# Altium schematic sheet 02 DE1-SoC Interface and All Devices.SchDoc("02 DE1-SoC Interface and All Devices")
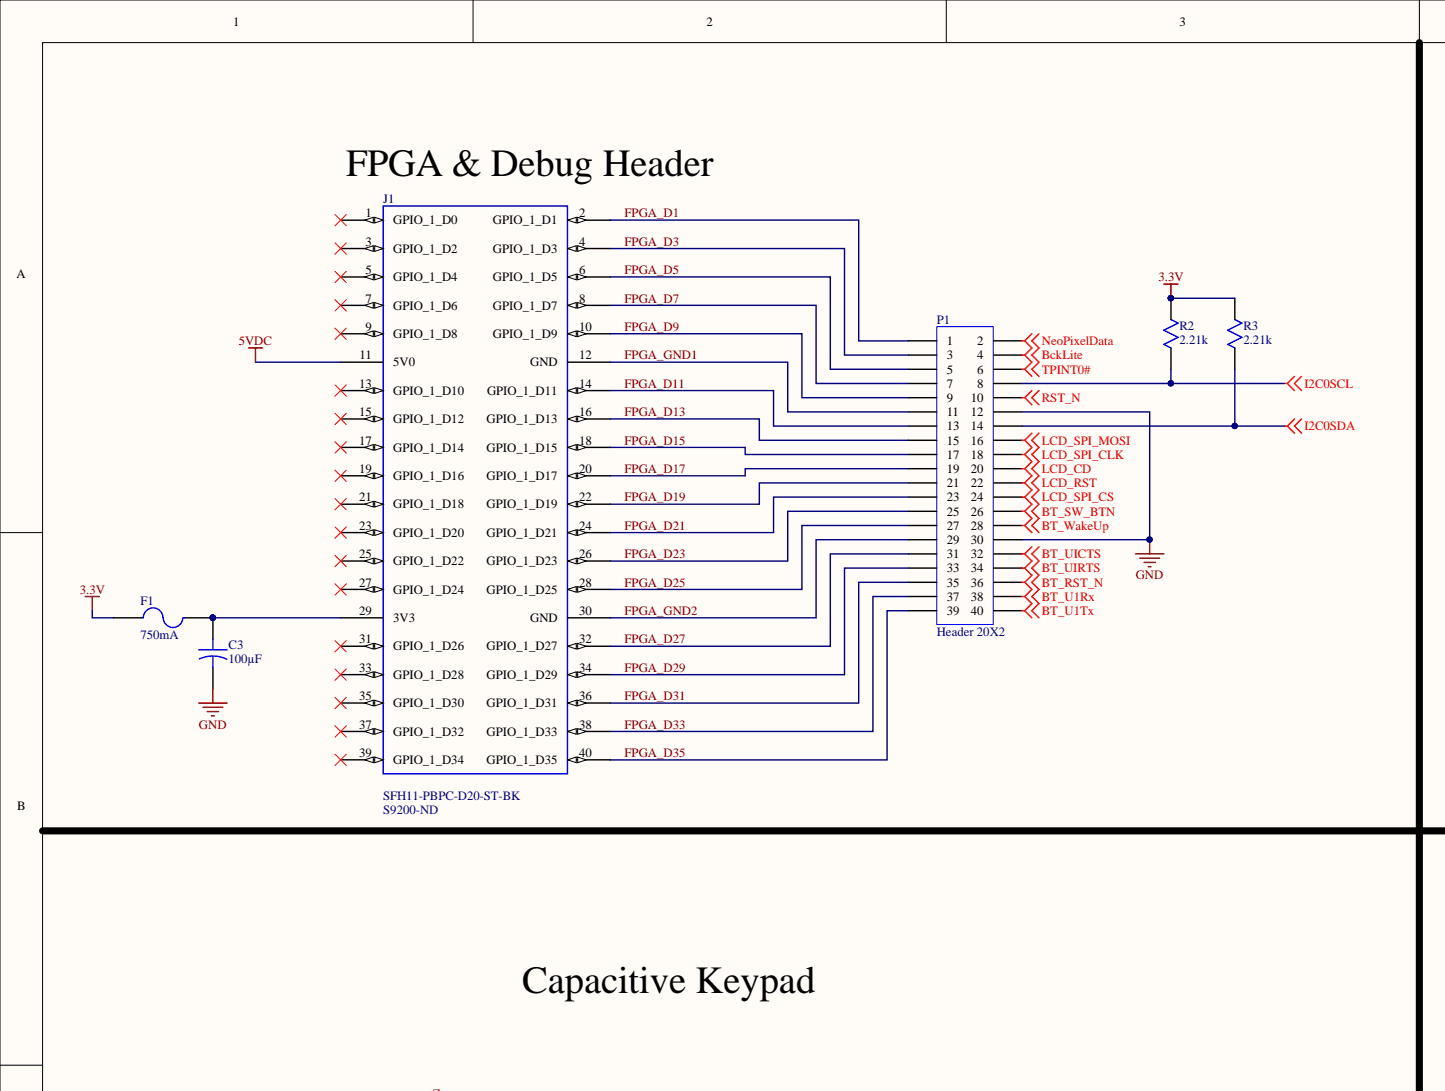
[diagram p0: 02 DE1-SoC Interface and All Devices.SchDoc, top left]
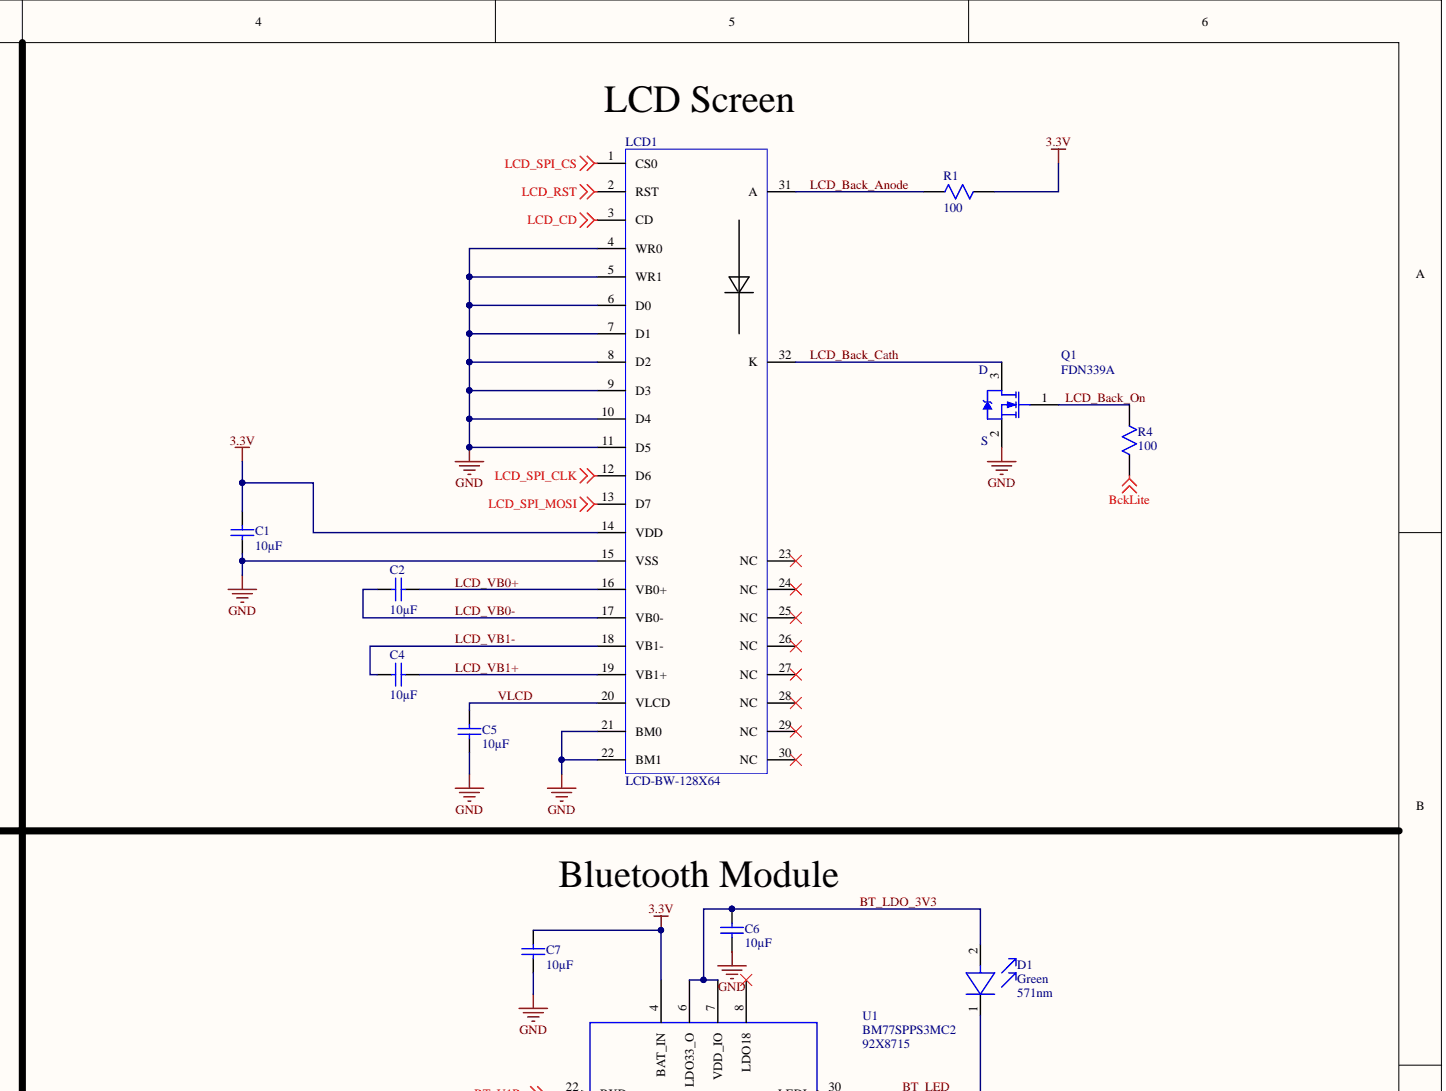
[diagram p1: 02 DE1-SoC Interface and All Devices.SchDoc, top right]
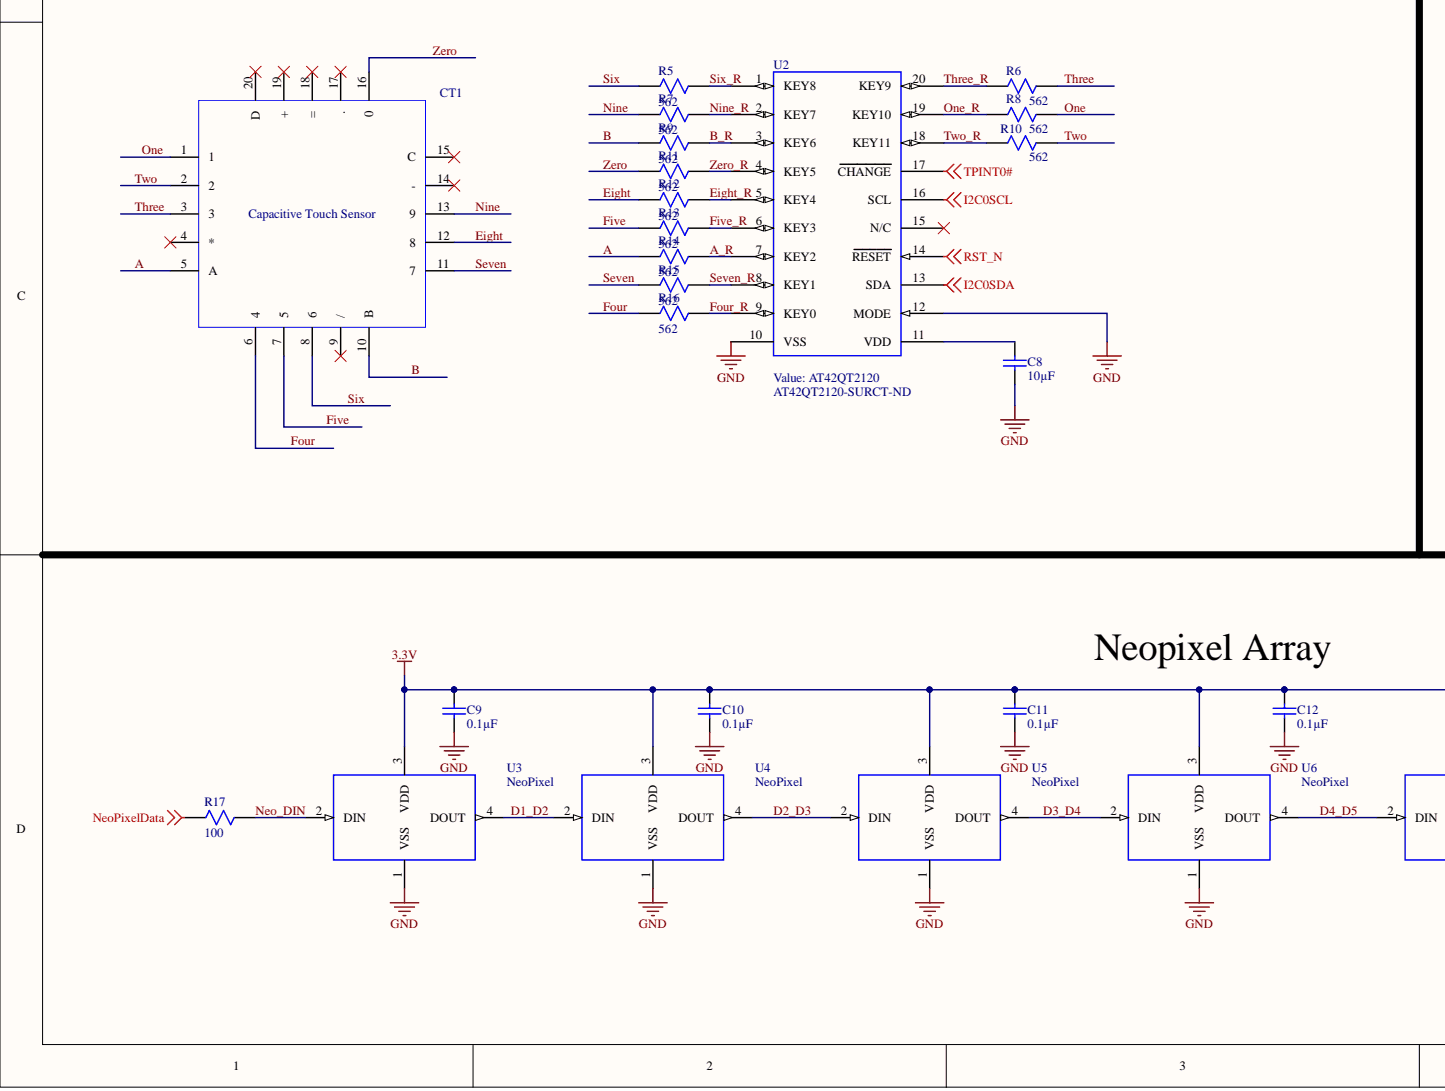
[diagram p2: 02 DE1-SoC Interface and All Devices.SchDoc, bottom left]
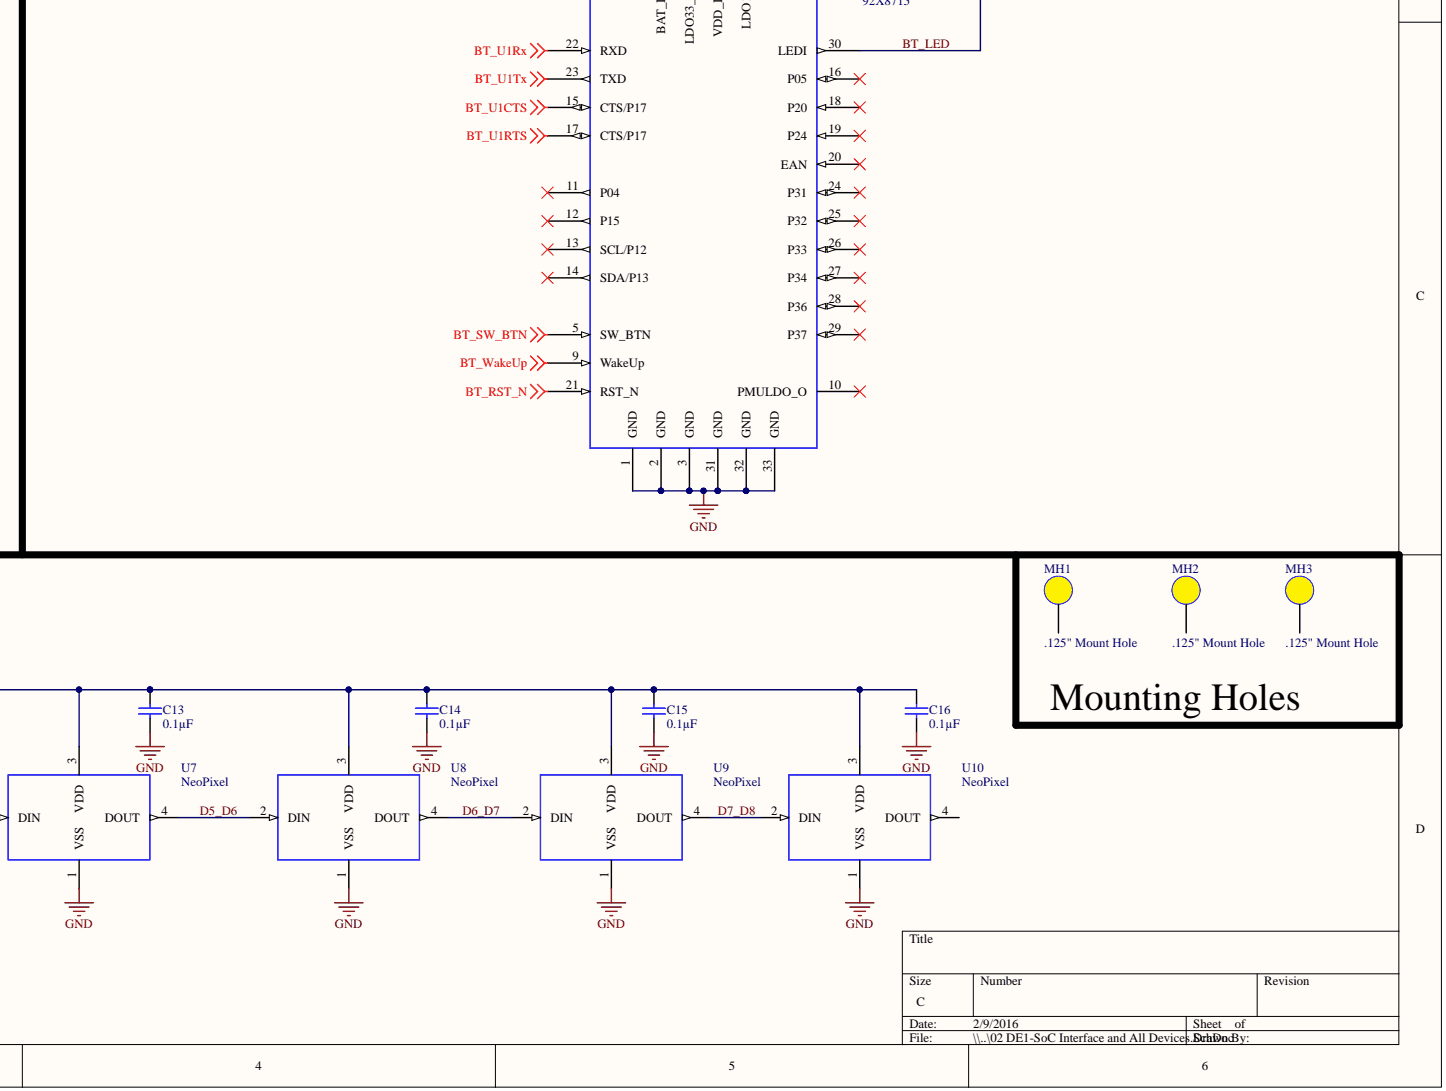
[diagram p3: 02 DE1-SoC Interface and All Devices.SchDoc, bottom right]

## Parts
C1  value 10µF  [CAP_0805]  (hidden comment: CAP_0805_U_010_00)
C2  value 10µF  [CAP_0805]  (hidden comment: CAP_0805_U_010_00)
C3  100µF  [PANACAP-C]
C4  value 10µF  [CAP_0805]  (hidden comment: CAP_0805_U_010_00)
C5  value 10µF  [CAP_0805]  (hidden comment: CAP_0805_U_010_00)
C6  value 10µF  [CAP_0805]  (hidden comment: CAP_0805_U_010_00)
C7  value 10µF  [CAP_0805]  (hidden comment: CAP_0805_U_010_00)
C8  value 10µF  [CAP_0805]  (hidden comment: CAP_0805_U_010_00)
C9  value 0.1µF  [CAP_0805]  (hidden comment: CAP_0805_U_000_10)
C10  value 0.1µF  [CAP_0805]  (hidden comment: CAP_0805_U_000_10)
C11  value 0.1µF  [CAP_0805]  (hidden comment: CAP_0805_U_000_10)
C12  value 0.1µF  [CAP_0805]  (hidden comment: CAP_0805_U_000_10)
C13  value 0.1µF  [CAP_0805]  (hidden comment: CAP_0805_U_000_10)
C14  value 0.1µF  [CAP_0805]  (hidden comment: CAP_0805_U_000_10)
C15  value 0.1µF  [CAP_0805]  (hidden comment: CAP_0805_U_000_10)
C16  value 0.1µF  [CAP_0805]  (hidden comment: CAP_0805_U_000_10)
CT1  Capacitive Touch Sensor  [TOUCHPAD-12PIN]
D1  Color: Green  Wavelength - Dominant: 571nm  [LED_GREEN_0805]  (hidden comment: LED_GREEN_0805)
F1  750mA  [FUSE_0805]
J1  SFH11-PBPC-D20-ST-BK  Connector Type: Header  Supplier Part Number 1: S9200-ND  [Sullins_SFH11-PBPC-D20-ST-BK]
LCD1  LCD-BW-128X64  [ERC12864-655]
MH1  .125" Mount Hole  [MTHOLE_125-300KeepOut]
MH2  .125" Mount Hole  [MTHOLE_125-300KeepOut]
MH3  .125" Mount Hole  [MTHOLE_125-300KeepOut]
P1  Header 20X2  [HDR2X20]
Q1  FDN339A  [SOT23-3M]
R1  value 100  [RES_0805]  (hidden comment: RES_0805_R_100_00)
R2  value 2.21k  [RES_0805]  (hidden comment: RES_0805_K_002_21)
R3  value 2.21k  [RES_0805]  (hidden comment: RES_0805_K_002_21)
R4  value 100  [RES_0805]  (hidden comment: RES_0805_R_100_00)
R5  value 562  [RES_0805]  (hidden comment: RES_0805_R_562_00)
R6  value 562  [RES_0805]  (hidden comment: RES_0805_R_562_00)
R7  value 562  [RES_0805]  (hidden comment: RES_0805_R_562_00)
R8  value 562  [RES_0805]  (hidden comment: RES_0805_R_562_00)
R9  value 562  [RES_0805]  (hidden comment: RES_0805_R_562_00)
R10  value 562  [RES_0805]  (hidden comment: RES_0805_R_562_00)
R11  value 562  [RES_0805]  (hidden comment: RES_0805_R_562_00)
R12  value 562  [RES_0805]  (hidden comment: RES_0805_R_562_00)
R13  value 562  [RES_0805]  (hidden comment: RES_0805_R_562_00)
R14  value 562  [RES_0805]  (hidden comment: RES_0805_R_562_00)
R15  value 562  [RES_0805]  (hidden comment: RES_0805_R_562_00)
R16  value 562  [RES_0805]  (hidden comment: RES_0805_R_562_00)
R17  value 100  [RES_0805]  (hidden comment: RES_0805_R_100_00)
U1  BM77SPPS3MC2  Supplier Part Number 1: 92X8715  [BM77SPPS3MC2]
U2  AT42QT2120-SUR  value AT42QT2120  Supplier Part Number 1: AT42QT2120-SURCT-ND  [SOIC127P1030X265-20N]
U3  NeoPixel  [WS2812B]
U4  NeoPixel  [WS2812B]
U5  NeoPixel  [WS2812B]
U6  NeoPixel  [WS2812B]
U7  NeoPixel  [WS2812B]
U8  NeoPixel  [WS2812B]
U9  NeoPixel  [WS2812B]
U10  NeoPixel  [WS2812B]

## Nets
3.3V: C1.1 C7.2 C9.2 C10.2 C11.2 C12.2 C13.2 C14.2 C15.2 C16.2 F1.1 LCD1.14(VDD) R1.2 R2.1 R3.1 U1.4(BAT_IN) U3.3(VDD) U4.3(VDD) U5.3(VDD) U6.3(VDD) U7.3(VDD) U8.3(VDD) U9.3(VDD) U10.3(VDD)
5VDC: J1.11(5V0)
BCKLITE: P1.4 R4.1
BT_RST_N: P1.36 U1.21(RST_N)
BT_SW_BTN: P1.26 U1.5(SW_BTN)
BT_U1CTS: U1.15(CTS/P17)
BT_U1RTS: U1.17(CTS/P17)
BT_U1RX: P1.38 U1.22(RXD)
BT_U1TX: P1.40 U1.23(TXD)
BT_UICTS: P1.32
BT_UIRTS: P1.34
BT_WAKEUP: P1.28 U1.9(WakeUp)
GND: C1.2 C3.- C5.1 C6.1 C7.1 C8.1 C9.1 C10.1 C11.1 C12.1 C13.1 C14.1 C15.1 C16.1 LCD1.4(WR0) LCD1.5(WR1) LCD1.6(D0) LCD1.7(D1) LCD1.8(D2) LCD1.9(D3) LCD1.10(D4) LCD1.11(D5) LCD1.15(VSS) LCD1.21(BM0) LCD1.22(BM1) P1.12 P1.30 Q1.2 U1.1(GND) U1.2(GND) U1.3(GND) U1.31(GND) U1.32(GND) U1.33(GND) U2.10(VSS) U2.12(MODE) U3.1(VSS) U4.1(VSS) U5.1(VSS) U6.1(VSS) U7.1(VSS) U8.1(VSS) U9.1(VSS) U10.1(VSS)
I2C0SCL: P1.8 R2.2 U2.16(SCL)
I2C0SDA: P1.14 R3.2 U2.13(SDA)
LCD_CD: LCD1.3(CD) P1.20
LCD_RST: LCD1.2(RST) P1.22
LCD_SPI_CLK: LCD1.12(D6) P1.18
LCD_SPI_CS: LCD1.1(CS0) P1.24
LCD_SPI_MOSI: LCD1.13(D7) P1.16
NEOPIXELDATA: P1.2 R17.1
RST_N: P1.10 U2.14(RESET)
TPINT0#: P1.6 U2.17(CHANGE)
A: CT1.5(A) R14.1
A_R: R14.2 U2.7(KEY2)
B: CT1.10(B) R9.1
B_R: R9.2 U2.3(KEY6)
BT_LDO_3V3: C6.2 D1.2 U1.6(LDO33_O) U1.7(VDD_IO)
BT_LED: D1.1 U1.30(LEDI)
D1_D2: U3.4(DOUT) U4.2(DIN)
D2_D3: U4.4(DOUT) U5.2(DIN)
D3_D4: U5.4(DOUT) U6.2(DIN)
D4_D5: U6.4(DOUT) U7.2(DIN)
D5_D6: U7.4(DOUT) U8.2(DIN)
D6_D7: U8.4(DOUT) U9.2(DIN)
D7_D8: U9.4(DOUT) U10.2(DIN)
EIGHT: CT1.12 R12.1
EIGHT_R: R12.2 U2.5(KEY4)
FIVE: CT1.7 R13.1
FIVE_R: R13.2 U2.6(KEY3)
FOUR: CT1.6 R16.1
FOUR_R: R16.2 U2.9(KEY0)
FPGA_D1: J1.2(GPIO_1_D1) P1.1
FPGA_D3: J1.4(GPIO_1_D3) P1.3
FPGA_D5: J1.6(GPIO_1_D5) P1.5
FPGA_D7: J1.8(GPIO_1_D7) P1.7
FPGA_D9: J1.10(GPIO_1_D9) P1.9
FPGA_D11: J1.14(GPIO_1_D11) P1.13
FPGA_D13: J1.16(GPIO_1_D13) P1.15
FPGA_D15: J1.18(GPIO_1_D15) P1.17
FPGA_D17: J1.20(GPIO_1_D17) P1.19
FPGA_D19: J1.22(GPIO_1_D19) P1.21
FPGA_D21: J1.24(GPIO_1_D21) P1.23
FPGA_D23: J1.26(GPIO_1_D23) P1.25
FPGA_D25: J1.28(GPIO_1_D25) P1.27
FPGA_D27: J1.32(GPIO_1_D27) P1.31
FPGA_D29: J1.34(GPIO_1_D29) P1.33
FPGA_D31: J1.36(GPIO_1_D31) P1.35
FPGA_D33: J1.38(GPIO_1_D33) P1.37
FPGA_D35: J1.40(GPIO_1_D35) P1.39
FPGA_GND1: J1.12(GND) P1.11
FPGA_GND2: J1.30(GND) P1.29
LCD_BACK_ANODE: LCD1.31(A) R1.1
LCD_BACK_CATH: LCD1.32(K) Q1.3
LCD_BACK_ON: Q1.1 R4.2
LCD_VB0+: C2.1 LCD1.16(VB0+)
LCD_VB0-: C2.2 LCD1.17(VB0-)
LCD_VB1+: C4.1 LCD1.19(VB1+)
LCD_VB1-: C4.2 LCD1.18(VB1-)
NEO_DIN: R17.2 U3.2(DIN)
NINE: CT1.13 R7.1
NINE_R: R7.2 U2.2(KEY7)
ONE: CT1.1 R8.1
ONE_R: R8.2 U2.19(KEY10)
SEVEN: CT1.11 R15.1
SEVEN_R: R15.2 U2.8(KEY1)
SIX: CT1.8 R5.1
SIX_R: R5.2 U2.1(KEY8)
THREE: CT1.3 R6.1
THREE_R: R6.2 U2.20(KEY9)
TWO: CT1.2 R10.1
TWO_R: R10.2 U2.18(KEY11)
VLCD: C5.2 LCD1.20(VLCD)
ZERO: CT1.16 R11.1
ZERO_R: R11.2 U2.4(KEY5)
NetC3_+: C3.+ F1.2 J1.29(3V3)
NetC8_2: C8.2 U2.11(VDD)

Not connected: CT1 4(*) 9(/) 14(-) 15(C) 17(.) 18(=) 19(+) 20(D); J1 1(GPIO_1_D0) 3(GPIO_1_D2) 5(GPIO_1_D4) 7(GPIO_1_D6) 9(GPIO_1_D8) 13(GPIO_1_D10) 15(GPIO_1_D12) 17(GPIO_1_D14) 19(GPIO_1_D16) 21(GPIO_1_D18) 23(GPIO_1_D20) 25(GPIO_1_D22) 27(GPIO_1_D24) 31(GPIO_1_D26) 33(GPIO_1_D28) 35(GPIO_1_D30) 37(GPIO_1_D32) 39(GPIO_1_D34); LCD1 23(NC) 24(NC) 25(NC) 26(NC) 27(NC) 28(NC) 29(NC) 30(NC); MH1 1; MH2 1; MH3 1; U1 8(LDO18) 10(PMULDO_O) 11(P04) 12(P15) 13(SCL/P12) 14(SDA/P13) 16(P05) 18(P20) 19(P24) 20(EAN) 24(P31) 25(P32) 26(P33) 27(P34) 28(P36) 29(P37); U2 15(N/C); U10 4(DOUT)

## Library data of the parts
C1 C2 C4-C8 = CAP_0805_U_010_00: CAP CER 10UF 10V X5R 0805; Manufacturer: Samsung Electro-Mechanics America, Inc.; Manufacturer Part Number: CL21A106KPCLQNC; Tolerance: ±10%; Applications: General Purpose; Category: Capacitors; Component Kind: Standard; ComponentLink1Description: http://media.digikey.com/pdf/Data%20Sheets/Samsung%20PDFs/CL21A106KPCLQNC_character.pdf; ComponentLink1URL: http://media.digikey.com/pdf/Data%20Sheets/Samsung%20PDFs/CL21A106KPCLQNC_character.pdf; Features: -; Height - Seated (Max): -; Lead Spacing: -; Lead Style: -; Mounting Type: Surface Mount, MLCC; Operating Temperature: -55°C ~ 85°C; Package / Case: 0805 (2012 Metric); Packaging: Cut Tape (CT); Pricing 1: 1=0.2, 10=0.14, 25=0.1052, 50=0.0806, 100=0.0665, 500=0.0469, 1000=0.0385 (USD); Ratings: -; RoHS: RoHS Compliant; Size / Dimension: 0.079" L x 0.049" W (2.00mm x 1.25mm); Stock 1: 97192; Supplier 1: Digi-Key; Supplier Part Number 1: 1276-2402-1-ND; Temperature Coefficient: X5R; Thickness (Max): 0.037" (0.95mm); Voltage - Rated: 10V; Library Name: ECE453Spring16.SchLib
C3 = CAP_ALUM_U_100_00: CAP ALUM 100UF 20% 10V SMD; Manufacturer: Panasonic Electronic Components; Manufacturer Part Number: EEE-1AA101WR; Lifetime @ Temp.: 1000 Hrs @ 85°C; Ripple Current: 50mA; Surface Mount Land Size: 0.209" L x 0.209" W (5.30mm x 5.30mm); Applications: Automotive; Category: Capacitors; Component Kind: Standard; ComponentLink1Description: http://media.digikey.com/pdf/Data%20Sheets/Panasonic%20Electronic%20Components/S_Series_Type_V.pdf; ComponentLink1URL: http://media.digikey.com/pdf/Data%20Sheets/Panasonic%20Electronic%20Components/S_Series_Type_V.pdf; ESR (Equivalent Series Resistance): -; Height - Seated (Max): 0.213" (5.40mm); Impedance: -; Lead Spacing: -; Mounting Type: Surface Mount; Operating Temperature: -40°C ~ 85°C; Package / Case: Radial, Can - SMD; Packaging: Cut Tape (CT); Pricing 1: 1=0.44, 10=0.345, 25=0.2904, 50=0.249, 100=0.2076, 250=0.15568, 500=0.13284 (USD); RoHS: RoHS Compliant; Size / Dimension: 0.197" Dia (5.00mm); Stock 1: 104778; Supplier 1: Digi-Key; Supplier Part Number 1: PCE3867CT-ND; Tolerance: ±20%; Type: -; Value: 100µF; Voltage Rating: 10V; Library Name: ECE453Spring16.SchLib
C9-C16 = CAP_0805_U_000_10: CAP CER 0.1UF 50V Y5V 0805; Manufacturer: Yageo; Manufacturer Part Number: CC0805ZRY5V9BB104; Temperature Coefficient: Y5V (F); Tolerance: -20%, +80%; Applications: General Purpose; Category: Capacitors; Component Kind: Standard; ComponentLink1Description: http://www.yageo.com/documents/recent/UPY-GPHC_Y5V_6.3V-to-50V_5_revised.pdf; ComponentLink1URL: http://www.yageo.com/documents/recent/UPY-GPHC_Y5V_6.3V-to-50V_5_revised.pdf; Features: -; Height - Seated (Max): -; Lead Spacing: -; Lead Style: -; Mounting Type: Surface Mount, MLCC; Operating Temperature: -30°C ~ 85°C; Package / Case: 0805 (2012 Metric); Packaging: Cut Tape (CT); Pricing 1: 1=0.1, 10=0.031, 25=0.022, 100=0.0144, 250=0.01176, 500=0.01004, 1000=0.00783 (USD); Ratings: -; RoHS: RoHS Compliant; Size / Dimension: 0.079" L x 0.049" W (2.00mm x 1.25mm); Stock 1: 86324; Supplier 1: Digi-Key; Supplier Part Number 1: 311-1361-1-ND; Thickness (Max): 0.024" (0.60mm); Voltage - Rated: 50V; Library Name: ECE453Spring16.SchLib
CT1 = Touch Pad: Component Kind: Standard; Library Name: ECE453Spring16.SchLib
D1 = LED_GREEN_0805: LED GREEN CLEAR 0805 SMD; Manufacturer: Lite-On Inc.; Manufacturer Part Number: LTST-C171KGKT; Current - Test: 20mA; Lens Color: Colorless; Lens Style/Size: Rectangle with Flat Top, 1.40mm x 1.25mm; Millicandela Rating: 35mcd; Viewing Angle: 130°; Voltage - Forward (Vf) (Typ): 2V; Wavelength - Peak: 574nm; Category: Optoelectronics; Component Kind: Standard; ComponentLink1Description: http://optoelectronics.liteon.com/upload/download/DS22-2000-118/S_110_LTST-C171KGKT.pdf; ComponentLink1URL: http://optoelectronics.liteon.com/upload/download/DS22-2000-118/S_110_LTST-C171KGKT.pdf; Features: -; Height (Max): 0.80mm; Lens Transparency: Clear; Mounting Type: Surface Mount; Package / Case: 0805 (2012 Metric); Packaging: Cut Tape (CT); Pricing 1: 1=0.27, 10=0.213, 100=0.1161, 250=0.0774, 500=0.0658, 1000=0.05031 (USD); RoHS: RoHS Compliant; Size / Dimension: 2.00mm L x 1.25mm W; Stock 1: 82505; Supplier 1: Digi-Key; Supplier Part Number 1: 160-1426-1-ND; Library Name: ECE453Spring16.SchLib
F1 = FUSE_0805_750mA: PTC RESTTBLE 0.75A 6V CHIP 0805; Manufacturer: Bel Fuse Inc.; Manufacturer Part Number: 0ZCK0075FF2E; Current - Max: 40A; Current - Trip (It): 1.5A; R Min/Max: 0.090 ~ 0.350 Ohm; Time to Trip: 0.2s; Category: Circuit Protection; Component Kind: Standard; ComponentLink1Description: http://www.belfuse.com/pdfs/0ZCK.pdf; ComponentLink1URL: http://www.belfuse.com/pdfs/0ZCK.pdf; Current - Hold (Ih) (Max): 750mA; Package / Case: 0805 (2012 Metric), Concave; Packaging: Cut Tape (CT); Pricing 1: 1=0.19, 10=0.176, 25=0.1628, 100=0.1463, 250=0.13028, 500=0.10858, 1000=0.10288 (USD); RoHS: RoHS Compliant; Stock 1: 18309; Supplier 1: Digi-Key; Supplier Part Number 1: 507-1814-1-ND; Voltage - Max: 6V; Library Name: ECE453Spring16.SchLib
J1 = SFH11-PBPC-D20-ST-BK: GPIO_1_Header_Physical; Manufacturer: Sullins Connector Solutions; Number of Positions: 40; Number of Positions Loaded: All; Pitch: 0.100" (2.54mm); Category: Connectors, Interconnects; Color: Black; Component Kind: Standard; ComponentLink1Description: http://sullinscorp.com/catalogs/146_PAGE119_.100_SFH11_SERIES_FEMALE_HDR_ST_RA.pdf; ComponentLink1URL: http://sullinscorp.com/catalogs/146_PAGE119_.100_SFH11_SERIES_FEMALE_HDR_ST_RA.pdf; Contact Finish: Gold; Contact Finish Thickness: Flash; Contact Type: Female Socket; Fastening Type: -; Features: Polarizing Key; Manufacturer Part Number: SFH11-PBPC-D20-ST-BK; Mounting Type: Through Hole; Number of Rows: 2; Packaging: Tray; Pricing 1: 1=2.31, 10=1.913, 25=1.7932, 50=1.594, 100=1.4346, 250=1.32304, 500=1.1955, 1000=1.06001, 2500=1.01618 (USD); RoHS: RoHS Compliant; Row Spacing: 0.100" (2.54mm); Stock 1: 5662; Supplier 1: Digi-Key; Termination: Solder; Value: SFH11-PBPC-D20-ST-BK; Library Name: ECE453Spring16.SchLib
LCD1 = ERC12864-655: Component Kind: Standard; Library Name: ECE453Spring16.SchLib
MH1-MH3 = MH-125: .125" Mount Hole with .300" Keepout; Component Kind: Standard; Library Name: ECE453Spring16.SchLib
P1 = Header 20X2: Header, 20-Pin, Dual row; Manufacturer: Sullins Connector Solutions; Manufacturer Part Number: PRPC020DAAN-RC; Connector Type: Header, Unshrouded, Breakaway; Number of Positions: 40; Number of Positions Loaded: All; Pitch: 0.100" (2.54mm); Category: Connectors, Interconnects; Color: Black; Component Kind: Standard; ComponentLink1Description: http://sullinscorp.com/drawings/294___R__C_____D___N-RC,_11636.pdf; ComponentLink1URL: http://sullinscorp.com/drawings/294___R__C_____D___N-RC,_11636.pdf; Contact Finish: Gold; Contact Finish Thickness: Flash; Contact Mating Length: 0.230" (5.84mm); Contact Type: Male Pin; Fastening Type: -; Features: -; LatestRevisionDate: 17-Jul-2002; LatestRevisionNote: Re-released for DXP Platform.; Mounting Type: Through Hole; Number of Rows: 2; Packaging: Bulk; Pricing 1: 1=0.93, 10=0.828, 100=0.6818, 500=0.53894, 1000=0.46264, 5000=0.42206, 10000=0.40583 (USD); RoHS: RoHS Compliant; Row Spacing: 0.100" (2.54mm); Signal Integrity: Connector; Stock 1: 501; Supplier 1: Digi-Key; Supplier Part Number 1: S2011EC-20-ND; Termination: Solder; Library Name: ECE453Spring16.SchLib; Publisher: Altium Limited
Q1 = FDN339AN: Nch MOSFET; Manufacturer: Fairchild Semiconductor; Current - Continuous Drain (Id) @ 25°C: 3A (Ta); Drain to Source Voltage (Vdss): 20V; FET Feature: Logic Level Gate, 2.5V Drive; FET Type: MOSFET N-Channel, Metal Oxide; Gate Charge (Qg) @ Vgs: 10nC @ 4.5V; Input Capacitance (Ciss) @ Vds: 700pF @ 10V; Power - Max: 460mW; Rds On (Max) @ Id, Vgs: 35 mOhm @ 3A, 4.5V; Vgs(th) (Max) @ Id: 1.5V @ 250µA; Category: Discrete Semiconductor Products; Component Kind: Standard; ComponentLink1Description: http://www.fairchildsemi.com/datasheets/FD/FDN339AN.pdf; ComponentLink1URL: http://www.fairchildsemi.com/datasheets/FD/FDN339AN.pdf; Manufacturer Part Number: FDN339AN; Mounting Type: Surface Mount; Package / Case: TO-236-3, SC-59, SOT-23-3; Packaging: Cut Tape (CT); Pricing 1: 1=0.54, 10=0.463, 100=0.3459, 500=0.27172, 1000=0.20948 (USD); RoHS: RoHS Compliant; Stock 1: 32837; Supplier 1: Digi-Key; Supplier Device Package: 3-SSOT; Supplier Part Number 1: FDN339ANCT-ND; Library Name: ECE453Spring16.SchLib
R1 R4 R17 = RES_0805_R_100_00: RES SMD 100 OHM 1% 1/8W 0805; Manufacturer: Yageo; Manufacturer Part Number: RC0805FR-07100RL; Power (Watts): 0.125W, 1/8W; Temperature Coefficient: ±100ppm/°C; Category: Resistors; Component Kind: Standard; ComponentLink1Description: http://www.yageo.com/NewPortal/yageodocoutput?fileName=/pdf/R-Chip/PYu-RC_Group_51_RoHS_L_3.pdf; ComponentLink1URL: http://www.yageo.com/NewPortal/yageodocoutput?fileName=/pdf/R-Chip/PYu-RC_Group_51_RoHS_L_3.pdf; Composition: Thick Film; Features: Moisture Resistant; Height: 0.024" (0.60mm); Number of Terminations: 2; Operating Temperature: -55°C ~ 155°C; Package / Case: 0805 (2012 Metric); Packaging: Cut Tape (CT); Pricing 1: 1=0.1, 10=0.021, 25=0.0152, 100=0.0084, 250=0.00644, 500=0.00514, 1000=0.00379, 2500=0.00329 (USD); RoHS: RoHS Compliant; Size / Dimension: 0.079" L x 0.049" W (2.00mm x 1.25mm); Stock 1: 1160362; Supplier 1: Digi-Key; Supplier Device Package: 0805; Supplier Part Number 1: 311-100CRCT-ND; Tolerance: ±1%; Library Name: ECE453Spring16.SchLib
R2 R3 = RES_0805_K_002_21: RES SMD 2.21K OHM 1% 1/8W 0805; Manufacturer: Yageo; Manufacturer Part Number: RC0805FR-072K21L; Power (Watts): 0.125W, 1/8W; Temperature Coefficient: ±100ppm/°C; Category: Resistors; Component Kind: Standard; ComponentLink1Description: http://www.yageo.com/NewPortal/yageodocoutput?fileName=/pdf/R-Chip/PYu-RC_Group_51_RoHS_L_3.pdf; ComponentLink1URL: http://www.yageo.com/NewPortal/yageodocoutput?fileName=/pdf/R-Chip/PYu-RC_Group_51_RoHS_L_3.pdf; Composition: Thick Film; Features: Moisture Resistant; Height: 0.024" (0.60mm); Number of Terminations: 2; Operating Temperature: -55°C ~ 155°C; Package / Case: 0805 (2012 Metric); Packaging: Cut Tape (CT); Pricing 1: 1=0.1, 10=0.021, 25=0.0152, 100=0.0084, 250=0.00644, 500=0.00514, 1000=0.00379, 2500=0.00329 (USD); RoHS: RoHS Compliant; Size / Dimension: 0.079" L x 0.049" W (2.00mm x 1.25mm); Stock 1: 159809; Supplier 1: Digi-Key; Supplier Device Package: 0805; Supplier Part Number 1: 311-2.21KCRCT-ND; Tolerance: ±1%; Library Name: ECE453Spring16.SchLib
R5-R16 = RES_0805_R_562_00: RES SMD 562 OHM 1% 1/8W 0805; Manufacturer: Yageo; Manufacturer Part Number: RC0805FR-07562RL; Power (Watts): 0.125W, 1/8W; Temperature Coefficient: ±100ppm/°C; Category: Resistors; Component Kind: Standard; ComponentLink1Description: http://www.yageo.com/NewPortal/yageodocoutput?fileName=/pdf/R-Chip/PYu-RC_Group_51_RoHS_L_3.pdf; ComponentLink1URL: http://www.yageo.com/NewPortal/yageodocoutput?fileName=/pdf/R-Chip/PYu-RC_Group_51_RoHS_L_3.pdf; Composition: Thick Film; Features: Moisture Resistant; Height: 0.024" (0.60mm); Number of Terminations: 2; Operating Temperature: -55°C ~ 155°C; Package / Case: 0805 (2012 Metric); Packaging: Cut Tape (CT); Pricing 1: 1=0.1, 10=0.021, 25=0.0152, 100=0.0084, 250=0.00644, 500=0.00514, 1000=0.00379, 2500=0.00329 (USD); RoHS: RoHS Compliant; Size / Dimension: 0.079" L x 0.049" W (2.00mm x 1.25mm); Stock 1: 69944; Supplier 1: Digi-Key; Supplier Device Package: 0805; Supplier Part Number 1: 311-562CRCT-ND; Tolerance: ±1%; Library Name: ECE453Spring16.SchLib
U1 = BM77SPPS3MC2: Bluetooth BM77SPPS3MC2; Manufacturer: MICROCHIP; Manufacturer Part Number: BM77SPPS3MC2-0007AA; Component Kind: Standard; ComponentLink1Description: http://www.farnell.com/datasheets/1884772.pdf; ComponentLink1URL: http://www.farnell.com/datasheets/1884772.pdf; ComponentLink2Description: http://www.farnell.com/product360s/BM77SPPS3MC2-0007AA.php; ComponentLink2URL: http://www.farnell.com/product360s/BM77SPPS3MC2-0007AA.php; Pricing 1: 1=9.36, 26=8.49, 100=8.06 (USD); RoHS: YES; Stock 1: 58; Supplier 1: Newark; Value: BM77SPPS3MC2; Library Name: ECE453Spring16.SchLib
U2 = AT42QT2120-SUR: IC TOUCH SENSOR 12CH 20SOIC; Manufacturer: Atmel; Interface: I²C; LED Driver Channels: Up to 10; Number of Inputs: Up to 12; Proximity Detection: Yes; Voltage - Supply: 1.8 V ~ 5.5 V; Category: Sensors, Transducers; Component Kind: Standard; ComponentLink1Description: http://www.atmel.com/images/doc9634.pdf; ComponentLink1URL: http://www.atmel.com/images/doc9634.pdf; Current - Supply: -; Manufacturer Part Number: AT42QT2120-SUR; Operating Temperature: -40°C ~ 85°C; Package / Case: 20-SOIC (0.295", 7.50mm Width); Packaging: Cut Tape (CT); Pricing 1: 1=2.7, 10=2.436, 25=2.1828, 100=1.9627, 250=1.74276, 500=1.5228 (USD); Resolution: -; RoHS: RoHS Compliant; Stock 1: 1214; Supplier 1: Digi-Key; Supplier Device Package: 20-SOIC; Type: Buttons, Slider, Wheel; Library Name: ECE453Spring16.SchLib
U3-U10 = WS2812B-MA: LED RGB WS2812B; Manufacturer: Adafruit Industries LLC; Manufacturer Part Number: 1655; Category: Optoelectronics; Component Kind: Standard; ComponentLink1Description: http://www.adafruit.com/datasheets/WS2812B.pdf; ComponentLink1URL: http://www.adafruit.com/datasheets/WS2812B.pdf; Pricing 1: 1=4.5, 10=4.05 (USD); RoHS: RoHS Compliant; Stock 1: 672; Supplier 1: Digi-Key; Supplier Part Number 1: 1528-1104-ND; Library Name: ECE453Spring16.SchLib
